# Altium schematic sheet 05_User_Interface.SchDoc
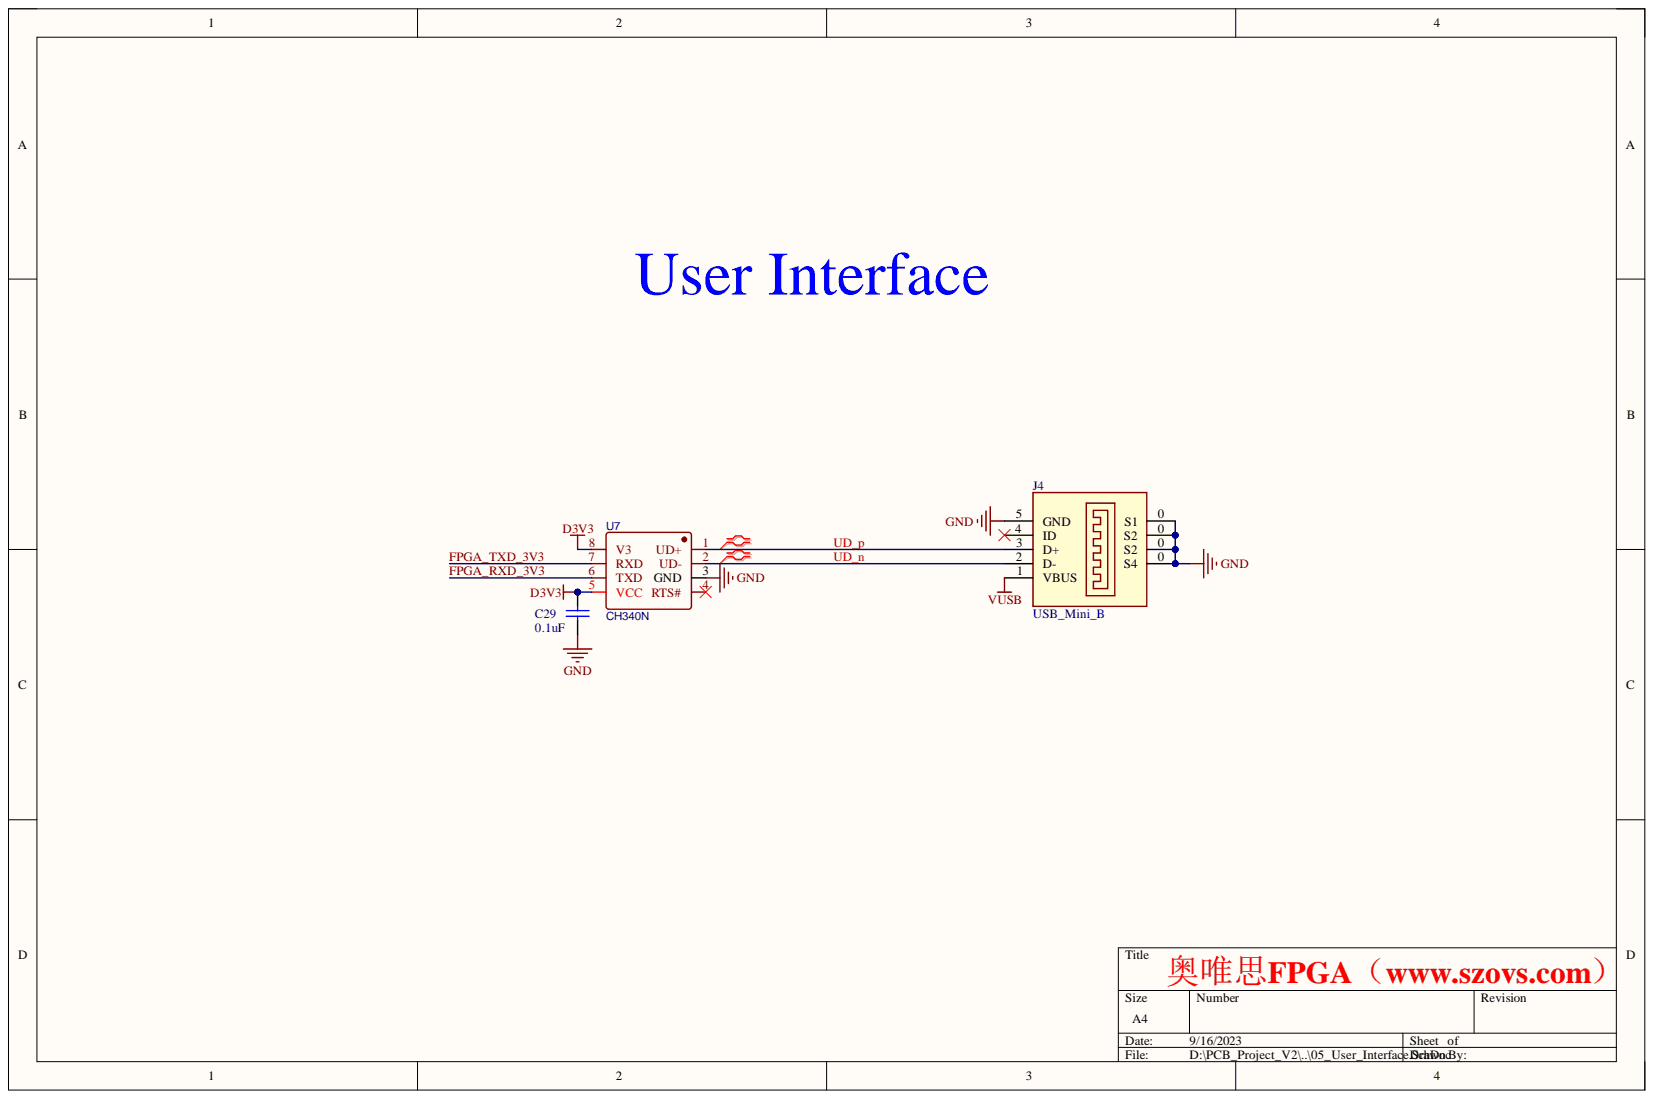
[diagram p0: 05_User_Interface.SchDoc]

## Parts
C29  0.1uF  [R0402]
J4  USB_Mini_B  [USB_mini_B]
U7  CH340N  [SOIC8]

## Nets
D3V3: C29.1 U7.5(VCC) U7.8(V3)
GND: C29.2 J4.0(S4) J4.5(GND) U7.3(GND)
VUSB: J4.1(VBUS)
FPGA_RXD_3V3: U7.6(TXD)
FPGA_TXD_3V3: U7.7(RXD)
UD_n: J4.2(D-) U7.2(UD-)
UD_p: J4.3(D+) U7.1(UD+)

Not connected: J4 4(ID); U7 4(RTS#)

## Library data of the parts
C29 = Cap: Capacitor; Component Kind: Standard; LatestRevisionDate: 17-Jul-2002; LatestRevisionNote: Re-released for DXP Platform.; Library Name: Crazy_PCB.SchLib; PackageReference: RAD-0.3; Published: 8-Jun-2000; Value: 0.1uF; Publisher: Altium Limited
J4 = USB_Mini: Maker: Molex; Model: 54819-0578; OrderNr: 418-0172; Component Kind: Standard; Distributor: RS; Library Name: Crazy_PCB.SchLib; Price: 4,29 (5)
U7 = CH330N: BOM_Manufacturer: WCH; BOM_JLCPCB Part Class: Extended Part; BOM_Manufacturer Part: CH330N; BOM_Supplier: LCSC; BOM_Supplier Part: C108996; Component Kind: Standard; spicePre: U; spiceSymbolName: CH330N
